# Altium schematic sheet 1  - Connectors.SchDoc("1  - Connectors")
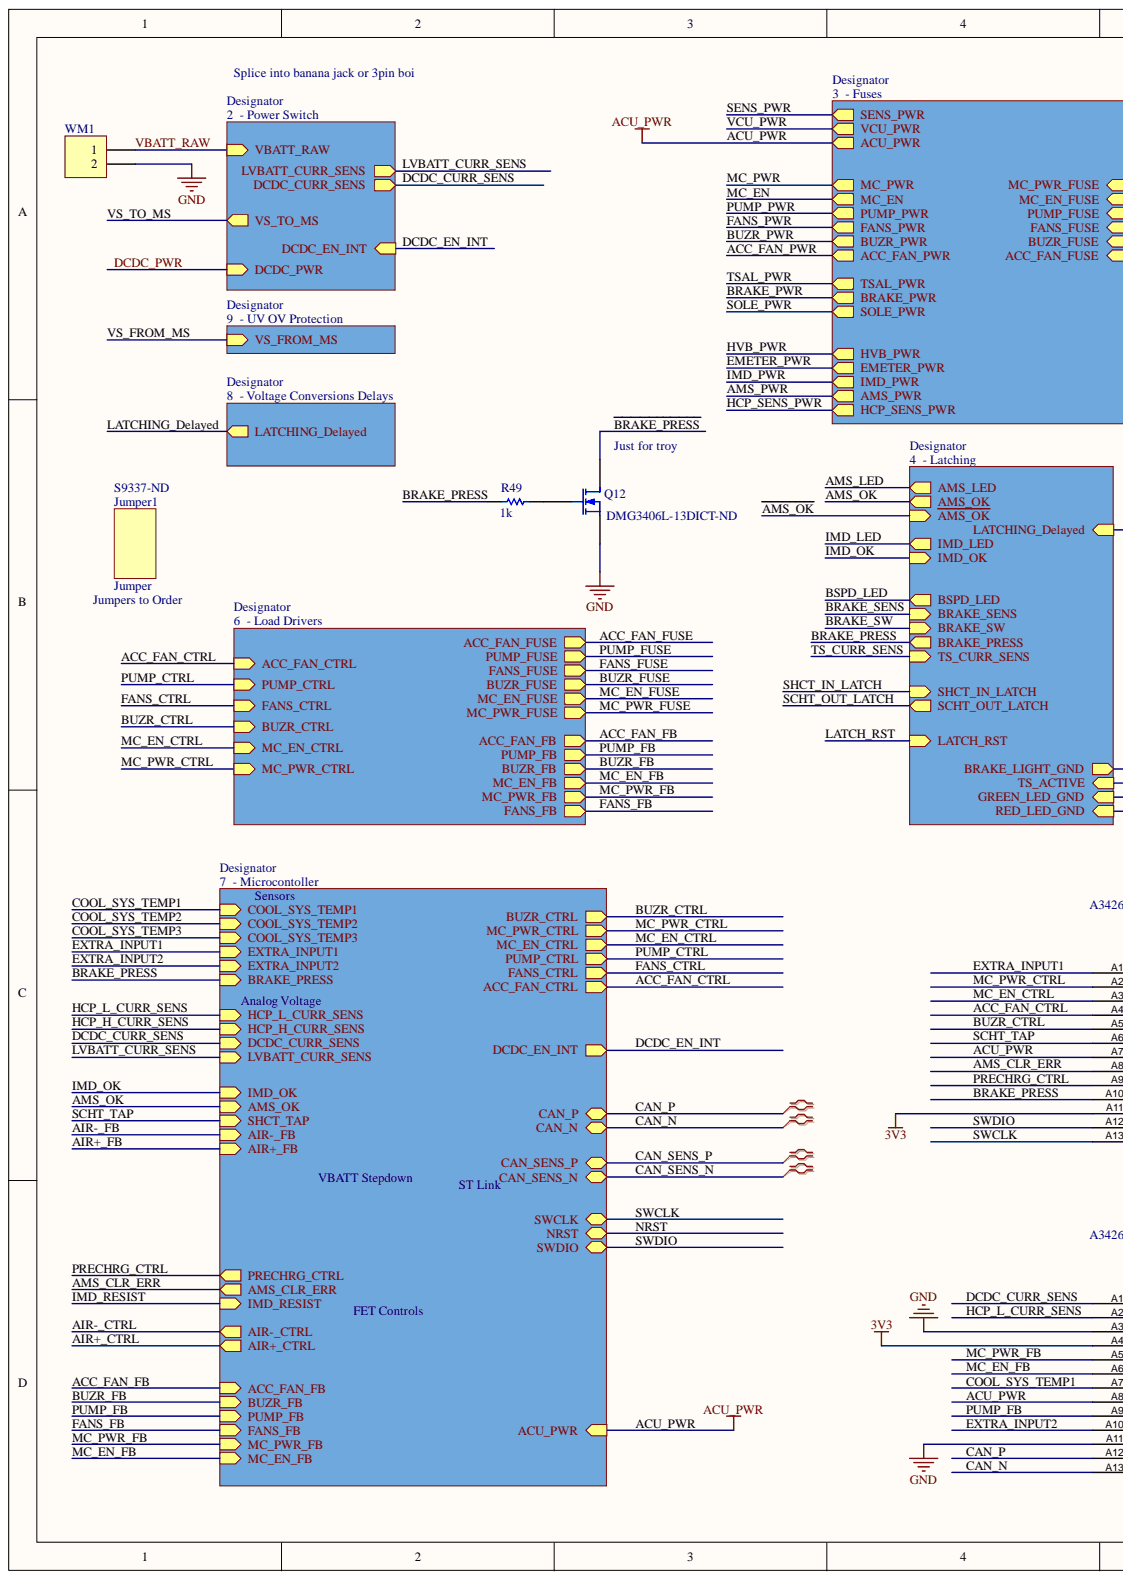
[diagram p0: 1  - Connectors.SchDoc, left]
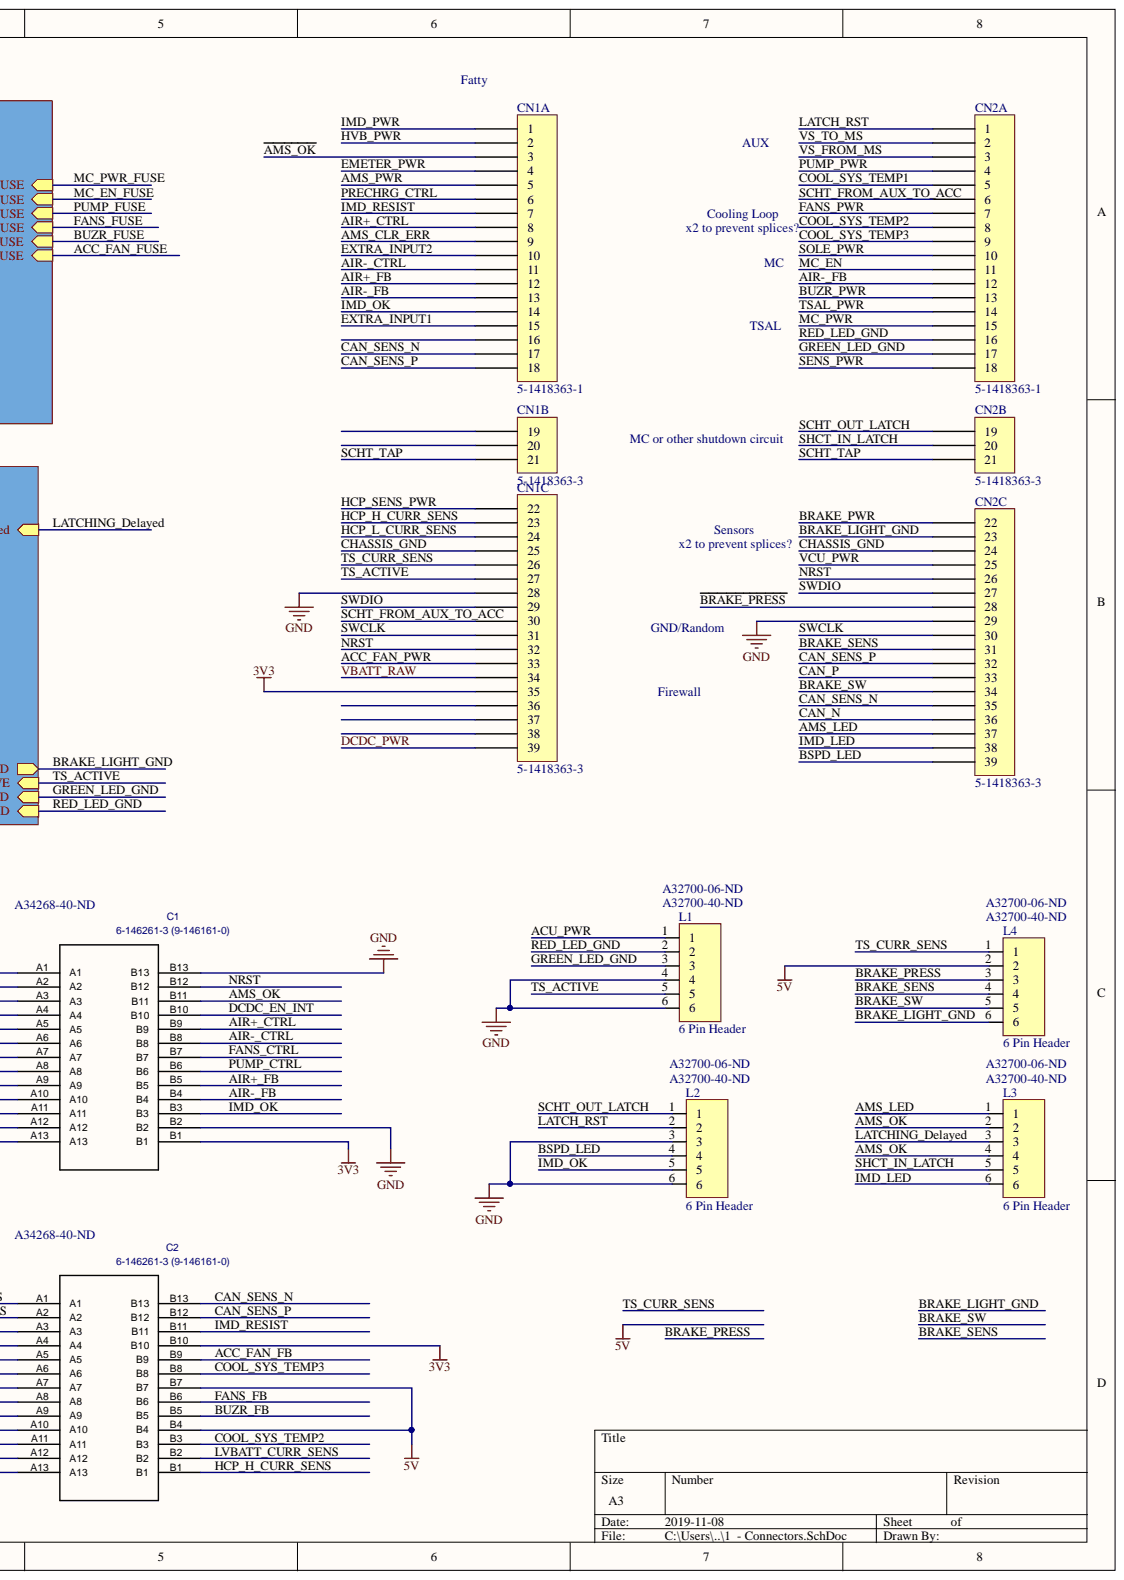
[diagram p1: 1  - Connectors.SchDoc, right]

## Parts
C1  6-146261-3 (9-146161-0)  Supplier Part Number 1: A34268-40-ND  [*TE_6-146257-3]
C2  6-146261-3 (9-146161-0)  Supplier Part Number 1: A34268-40-ND  [*TE_6-146257-3]
CN1  5-1418363-1  [LeavySeal 39 pin Ver]  (parts A,B,C)
CN2  5-1418363-1  [LeavySeal 39 pin Ver]  (parts A,B,C)
Jumper1  Jumper  Supplier Part Number 1: S9337-ND
L1  6 Pin Header  Supplier Part Number 2: A32700-06-ND  Supplier Part Number 1: A32700-40-ND  [5-146285-6]
L2  6 Pin Header  Supplier Part Number 2: A32700-06-ND  Supplier Part Number 1: A32700-40-ND  [5-146285-6]
L3  6 Pin Header  Supplier Part Number 2: A32700-06-ND  Supplier Part Number 1: A32700-40-ND  [5-146285-6]
L4  6 Pin Header  Supplier Part Number 2: A32700-06-ND  Supplier Part Number 1: A32700-40-ND  [5-146285-6]
Q12  Supplier Part Number 1: DMG3406L-13DICT-ND  [SOT-23_N]
R49  1k  [RESC0603(1608)_L]
WM1  WM9796-ND  [WM9796-ND]  (value not drawn)

## Nets
3V3: C1.A11 C1.B1 C2.A4 C2.B10 CN1.35
5V: C2.B4 C2.B7 L4.2
GND: C1.B2 C1.B13 C2.A3 C2.A11 CN1.28 CN2.29 L1.4 L1.6 L2.3 L2.6 Q12.2 WM1.2
~{AMS_OK}: CN1.3 L3.2
ACC_FAN_CTRL: C1.A4
ACC_FAN_FB: C2.B9
ACC_FAN_PWR: CN1.33
ACU_PWR: C1.A7 C2.A8 L1.1
AIR+_CTRL: C1.B9 CN1.8
AIR+_FB: C1.B5 CN1.12
AIR-_CTRL: C1.B8 CN1.11
AIR-_FB: C1.B4 CN1.13 CN2.12
AMS_CLR_ERR: C1.A8 CN1.9
AMS_LED: CN2.37 L3.1
AMS_OK: C1.B11 L3.4
AMS_PWR: CN1.5
~{BRAKE_PRESS}: CN2.28 Q12.3
BRAKE_LIGHT_GND: CN2.23 L4.6
BRAKE_PRESS: C1.A10 L4.3 R49.1
BRAKE_PWR: CN2.22
BRAKE_SENS: CN2.31 L4.4
BRAKE_SW: CN2.34 L4.5
BSPD_LED: CN2.39 L2.4
BUZR_CTRL: C1.A5
BUZR_FB: C2.B5
BUZR_PWR: CN2.13
CAN_N: C2.A13 CN2.36
CAN_P: C2.A12 CN2.33
CAN_SENS_N: C2.B13 CN1.17 CN2.35
CAN_SENS_P: C2.B12 CN1.18 CN2.32
CHASSIS_GND: CN1.25 CN2.24
COOL_SYS_TEMP1: C2.A7 CN2.5
COOL_SYS_TEMP2: C2.B3 CN2.8
COOL_SYS_TEMP3: C2.B8 CN2.9
DCDC_CURR_SENS: C2.A1
DCDC_EN_INT: C1.B10
DCDC_PWR: CN1.39
EMETER_PWR: CN1.4
EXTRA_INPUT1: C1.A1 CN1.15
EXTRA_INPUT2: C2.A10 CN1.10
FANS_CTRL: C1.B7
FANS_FB: C2.B6
FANS_PWR: CN2.7
GREEN_LED_GND: CN2.17 L1.3
HCP_H_CURR_SENS: C2.B1 CN1.23
HCP_L_CURR_SENS: C2.A2 CN1.24
HCP_SENS_PWR: CN1.22
HVB_PWR: CN1.2
IMD_LED: CN2.38 L3.6
IMD_OK: C1.B3 CN1.14 L2.5
IMD_PWR: CN1.1
IMD_RESIST: C2.B11 CN1.7
LATCH_RST: CN2.1 L2.2
LATCHING_Delayed: L3.3
LVBATT_CURR_SENS: C2.B2
MC_EN: CN2.11
MC_EN_CTRL: C1.A3
MC_EN_FB: C2.A6
MC_PWR: CN2.15
MC_PWR_CTRL: C1.A2
MC_PWR_FB: C2.A5
NRST: C1.B12 CN1.32 CN2.26
PRECHRG_CTRL: C1.A9 CN1.6
PUMP_CTRL: C1.B6
PUMP_FB: C2.A9
PUMP_PWR: CN2.4
RED_LED_GND: CN2.16 L1.2
SCHT_FROM_AUX_TO_ACC: CN1.30 CN2.6
SCHT_OUT_LATCH: CN2.19 L2.1
SCHT_TAP: C1.A6 CN1.21 CN2.21
SENS_PWR: CN2.18
SHCT_IN_LATCH: CN2.20 L3.5
SOLE_PWR: CN2.10
SWCLK: C1.A13 CN1.31 CN2.30
SWDIO: C1.A12 CN1.29 CN2.27
TS_ACTIVE: CN1.27 L1.5
TS_CURR_SENS: CN1.26 L4.1
TSAL_PWR: CN2.14
VBATT_RAW: CN1.34 WM1.1
VCU_PWR: CN2.25
VS_FROM_MS: CN2.3
VS_TO_MS: CN2.2
NetCN1_16: CN1.16
NetCN1_19: CN1.19
NetCN1_20: CN1.20
NetCN1_36: CN1.36
NetCN1_37: CN1.37
NetCN1_38: CN1.38
NetQ12_1: Q12.1 R49.2
ACC_FAN_FUSE:
BUZR_FUSE:
FANS_FUSE:
MC_EN_FUSE:
MC_PWR_FUSE:
PUMP_FUSE:

## Library data of the parts
C1 C2 = 6-534998-3: Vendor: TE Connectivity; C: Copyright (C) 2015 Accelerated Designs. All rights reserved; Built_By: EMA_UL_Team; Value: 6-534998-3; Supplier 1: Digi-Key; Manufacturer_Part_Number: 6-534998-3; Component Kind: Standard; Library Name: ACB_lib.SchLib
CN1 CN2 = 5-1418363-3: Levyseal Vertical 39 pin header; Component Kind: Standard; Library Name: ACB_lib.SchLib
Q12 = MOSFET-N: N-Channel MOSFET; Manufacturer: Infineon Technologies; Vgs(th) (Max) @ Id: 2.2V @ 250µA; Rds On (Max) @ Id, Vgs: 7.5 mOhm @ 30A, 10V; Power - Max: 47W; Input Capacitance (Ciss) @ Vds: 1900pF @ 15V; Gate Charge (Qg) @ Vgs: 18nC @ 10V; FET Type: MOSFET N-Channel, Metal Oxide; FET Feature: Logic Level Gate; Drain to Source Voltage (Vdss): 30V; Current - Continuous Drain (Id) @ 25°C: 50A (Tc); Supplier Device Package: PG-TO252-3; Supplier 1: Digi-Key; Simulation: NMOS; RoHS: RoHS Compliant; Published: 8-Jun-2000; Packaging: Cut Tape (CT); PackageVersion: 1995/1996; PackageReference: E3; PackageDescription: TO, Flat Index; 3 In-Line, Axial Leads; Body Dia. 4.6mm; Lead Dia. 0.5 mm (max); Package / Case: TO-252-3, DPak (2 Leads + Tab), SC-63; Mounting Type: Surface Mount; Library Name: Miscellaneous Devices.IntLib; LatestRevisionNote: Re-released for DXP Platform.; LatestRevisionDate: 17-Jul-2002; ComponentLink2URL: http://www.semicon.toshiba.co.jp/info/docget.jsp?type=datasheet&lang=en&pid=SSM3K329R; ComponentLink2Description: http://www.semicon.toshiba.co.jp/info/docget.jsp?type=datasheet&lang=en&pid=SSM3K329R; ComponentLink1URL: http://www.infineon.com/dgdl/Infineon-IPD075N03LG-DS-v02_01-en.pdf?folderId=5546d4694909da4801490a07012f053b&fileId=db3a30431936bc4b011951d824f83aeb; ComponentLink1Description: http://www.infineon.com/dgdl/Infineon-IPD075N03LG-DS-v02_01-en.pdf?folderId=5546d4694909da4801490a07012f053b&fileId=db3a30431936bc4b011951d824f83aeb; Component Kind: Standard; Category: Discrete Semiconductor Products; Publisher: Altium Limited
R49 = CMP-1591-00001-1: 0603 (1608 Metric) Chip Resistor; Case-Metric: 1608; Case-EIA: 0603; Value: 1k; Supplier Part Number 1: P1.00KHCT-ND; Supplier 1: Digi-Key; PackageReference: RESC0603(1608); Library Name: Altium Content Vault; Component Kind: Standard
WM1 = Header 2: Header, 2-Pin; Supplier Part Number 2: WM9796-ND; Supplier 2: Digi-Key; Supplier 1: Digi-Key; Library Name: Miscellaneous Connectors.IntLib; LatestRevisionNote: Re-released for DXP Platform.; LatestRevisionDate: 17-Jul-2002; Component Kind: Standard; Publisher: Altium Limited
Jumper1 = Jumper: Supplier 1: Digi-Key; Component Kind: Standard; Library Name: BRAKE TEMP Components.SchLib
L1-L4 = 6 Pin Header: Supplier 2: Digi-Key; Supplier 1: Digi-Key; Component Kind: Standard; Library Name: ACB_lib.SchLib
